# Altium schematic sheet Miscellenious.SchDoc(Miscellenious)
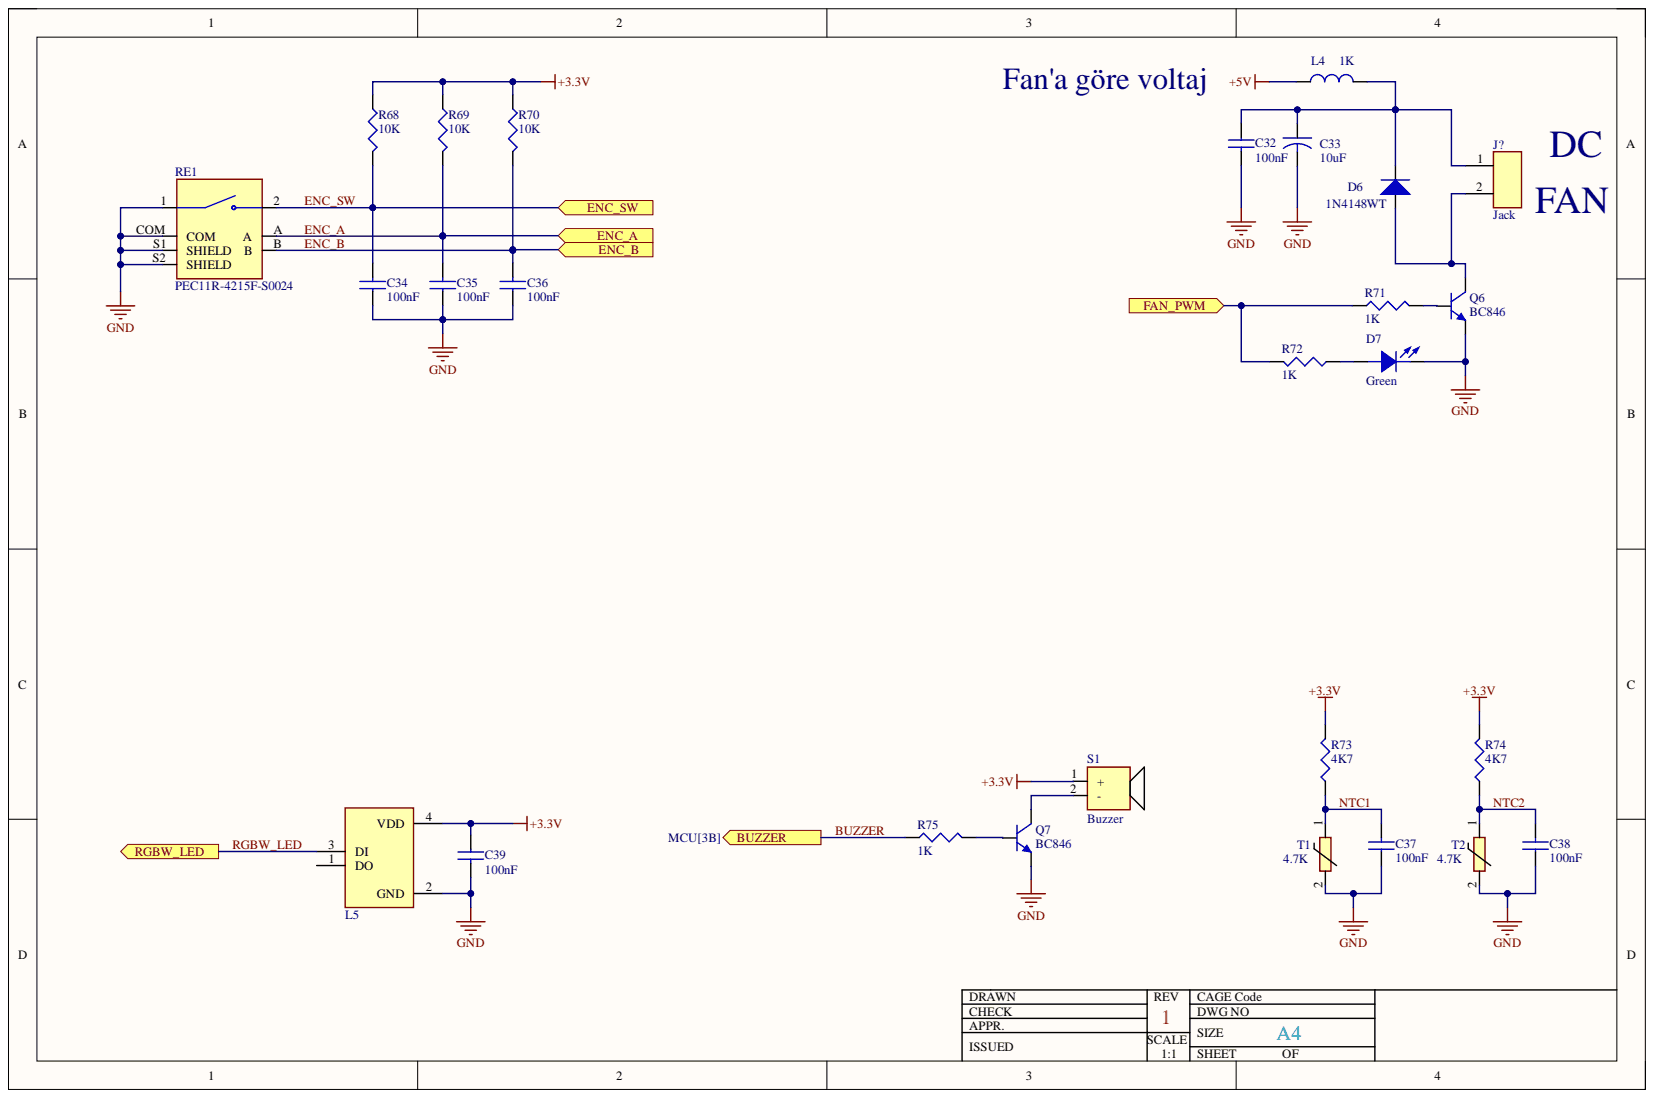
[diagram p0: Miscellenious.SchDoc]

## Parts
C32  value 100nF  [0805 SMD Ceramic Capacitor]  (hidden comment: C0805C104)
C33  value 10uF  [A Case]  (hidden comment: T491A106)
C34  value 100nF  [0805 SMD Ceramic Capacitor]  (hidden comment: C0805C104)
C35  value 100nF  [0805 SMD Ceramic Capacitor]  (hidden comment: C0805C104)
C36  value 100nF  [0805 SMD Ceramic Capacitor]  (hidden comment: C0805C104)
C37  value 100nF  [0805 SMD Ceramic Capacitor]  (hidden comment: C0805C104)
C38  value 100nF  [0805 SMD Ceramic Capacitor]  (hidden comment: C0805C104)
C39  value 100nF  [0805 SMD Ceramic Capacitor]  (hidden comment: C0805C104)
D6  1N4148WT  [SOD-523F]
D7  value Green  [0805 Green LED]  (hidden comment: 0805 Green LED)
J?  value Jack  [KF2EDGV-5.08-2P]  (hidden comment: KF2EDGV-5.08-2P)
L4  value 1K  [0805 Inductor]  (hidden comment: 0805Ferrite)
L5  SKC6812RGBW-NW  [SKC6812RGBW-NW]  (value not drawn)
Q6  BC846  [SOT23]
Q7  BC846  [SOT23]
R68  value 10K  [0805 Resistor]  (hidden comment: 0805_10K)
R69  value 10K  [0805 Resistor]  (hidden comment: 0805_10K)
R70  value 10K  [0805 Resistor]  (hidden comment: 0805_10K)
R71  value 1K  [0805 Resistor]  (hidden comment: 0805_1K)
R72  value 1K  [0805 Resistor]  (hidden comment: 0805_1K)
R73  value 4K7  [0805 Resistor]  (hidden comment: 0805_4K7%1)
R74  value 4K7  [0805 Resistor]  (hidden comment: 0805_4K7%1)
R75  value 1K  [0805 Resistor]  (hidden comment: 0805_1K)
RE1  PEC11R-4215F-S0024  [XDCR_PEC11R-4215F-S0024]
S1  value Buzzer  [MTL-8530]  (hidden comment: MTL-8530)
T1  value 4.7K  [1x2 2 Pin Header]  (hidden comment: L-KLS6-MF52-A2-472J-3950)
T2  value 4.7K  [1x2 2 Pin Header]  (hidden comment: L-KLS6-MF52-A2-472J-3950)

## Ports
BUZZER, ENC_A, ENC_B, ENC_SW, FAN_PWM, RGBW_LED

## Nets
+3.3V: C39.1 L5.4(VDD) R68.1 R69.1 R70.1 R73.2 R74.2 S1.1
+5V: L4.2
GND: C32.2 C33.2 C34.1 C35.1 C36.1 C37.1 C38.1 C39.2 D7.2 L5.2(GND) Q6.2 Q7.2 RE1.1 RE1.COM RE1.S1(SHIELD) RE1.S2(SHIELD) T1.2 T2.2
BUZZER: R75.1 ; port BUZZER
ENC_A: C35.2 R69.2 RE1.A ; port ENC_A
ENC_B: C36.2 R70.2 RE1.B ; port ENC_B
ENC_SW: C34.2 R68.2 RE1.2 ; port ENC_SW
FAN_PWM: R71.1 R72.2 ; port FAN_PWM
NTC1: C37.2 R73.1 T1.1
NTC2: C38.2 R74.1 T2.1
RGBW_LED: L5.3(DI) ; port RGBW_LED
NetC32_1: C32.1 C33.1 D6.1 J?.1 L4.1
NetD6_2: D6.2 J?.2 Q6.3
NetD7_1: D7.1 R72.1
NetQ6_1: Q6.1 R71.2
NetQ7_1: Q7.1 R75.2
NetQ7_3: Q7.3 S1.2

Not connected: L5 1(DO)

## Library data of the parts
C32 C34-C39 = C0805C104: Manufacturer: KEMET; Manufacturer Code: C0805C104J5RACTU; Voltage: 50V; Component Kind: Standard; Farnell Code: 1414663; Library Name: Capacitors.SchLib; Package: 0805; Unit Price: 0,06 €
C33 = T491A106: Manufacturer: KEMET; Manufacturer Code: T491A106K006AT; Voltage: 6V3; Component Kind: Standard; Farnell Code: 1457412; Library Name: Capacitors.SchLib; Unit Price: 0,143 €
D6 = 1N4148WT: Diode 75 V 200mA Surface Mount SOD-523F; Manufacturer: MULTICOMP; Component Kind: Standard; Current: 200mA; Digikey Code: 1N4148WTCT-ND; Library Name: 1N4148.SchLib; Manufacturer Code: 1N4148WT; Price Unit: $; Unit Price: 0,1; Value: 1N4148WT
D7 = 0805 Green LED: Manufacturer: AVAGO Technologies; Manufacturer Code: HSMG-C170; Current: 20mA; Voltage: 2.2V; Component Kind: Standard; Farnell Code: 5790852; Library Name: LED.SchLib; Unit Price: 0,134 €
J? = KF2EDGV-5.08-2P: TERM BLOCK HDR 2POS 5.08mm; Manufacturer: Cixi Kefa Elec; Component Kind: Standard; Digikey Code: None; Library Name: Terminal.SchLib; Manufacturer Code: KF2EDGV-5.08-2P; Unit Price: 0.0417
L4 = 0805Ferrite: Manufacturer: TDK; Manufacturer Code: MMZ2012R102A; Current: 500mA; Component Kind: Standard; Farnell Code: 1669719; Library Name: FerriteBead.SchLib; Unit Price: 0,059 €
L5 = SKC6812RGBW-NW: LED RED, YELLOW, GREEN, BLUE, WHITE SMD; Manufacturer: OPSCO Optoelectronics; Value: RGBW; Component Kind: Standard; Library Name: RGB_LED.SchLib; Manufacturer Code: SKC6812RGBW-NW; Package: SMD-4P,5x4.9mm; Unit Price: 0.1341
Q6 Q7 = BC846: Manufacturer: NXP Semiconductors; Voltage: 65V; Component Kind: Standard; Farnell Code: 1081227; Library Name: BC846.SchLib; Manufacturer Code: BC846; Unit Price: 0,049 €; Value: BC846
R68-R70 = 0805_10K: Manufacturer: MULTICOMP; Manufacturer Code: MC 0.1W 0805 1% 10K; Tolerance: ±1%; Component Kind: Standard; Farnell Code: 9332391; Library Name: Resistors.SchLib; Unit Price: 0,03 €
R71 R72 R75 = 0805_1K: Manufacturer: MULTICOMP; Manufacturer Code: MC01W080511K; Tolerance: ±1%; Component Kind: Standard; Farnell Code: 9332383; Library Name: Resistors.SchLib; Unit Price: 0,03 €
R73 R74 = 0805_4K7%1: Manufacturer: WELWYN; Manufacturer Code: PCF0805P-R-4K7-BT1; Power Rating: 100mW; Temperature Coefficient: ±25ppm/°C; Tolerance: ±0.1%; Component Kind: Standard; Farnell Code: 1506096; Library Name: Resistors.SchLib; MOQ: 5; Unit Price: 0,34
RE1 = PEC11R-4215F-S0024: Incremental Encoder, 12 Mm, Contact Rating 10 Ma - 5 Vdc; Availability: Unavailable; Component Kind: Standard; Library Name: PEC11R-4215F-S0024.IntLib; MP: PEC11R-4215F-S0024; Package: None; Price: None
S1 = MTL-8530: 80dB Passive (Externally Driven) Electromagnetic 2.7kHz SMD,8.5x8.5mm Buzzers ROHS; Manufacturer: Jiangsu Huaneng Elec; Voltage: 2.5V~4.5V; Component Kind: Standard; Library Name: Buzzer.SchLib; Manufacturer Code: MTL-8530; Package: SMD,8.5x8.5mm; Price Unit: $; Unit Price: 0.1912
T1 T2 = L-KLS6-MF52-A2-472J-3950: THERMISTOR NTC 4K7 3mm 5%; Manufacturer: KLS ELECTRONIC; Component Kind: Standard; Library Name: NTC.SchLib; Manufacturer Code: L-KLS6-MF52-A2-472J-3950; Package: THT; Unit Price: 0.08
